# Altium schematic sheet CurrentAndVoltageSetRev2b.SchDoc
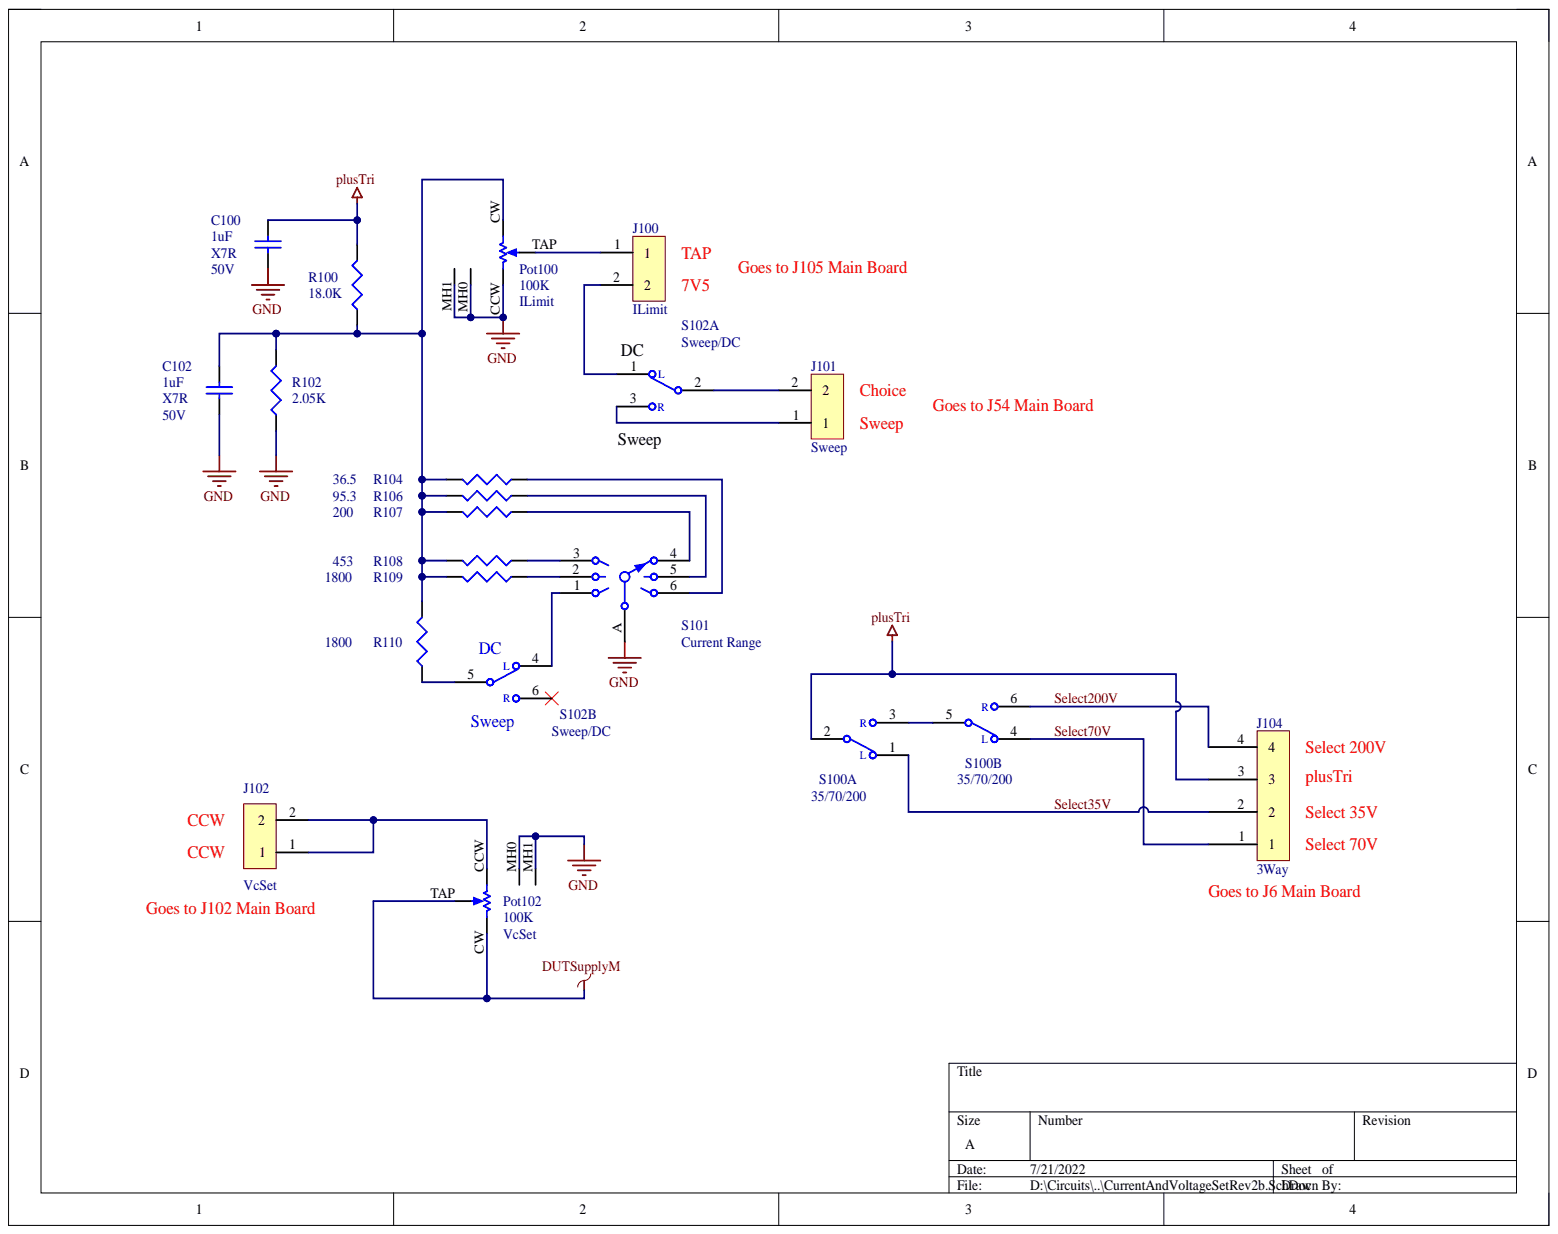
[diagram p0: CurrentAndVoltageSetRev2b.SchDoc]

## Parts
C100  1uF  Dielectric: X7R  Voltage: 50V  [CAPC2012M]
C102  1uF  Dielectric: X7R  Voltage: 50V  [CAPC2012M]
J100  ILimit  [DTA-100-2]
J101  Sweep  [DTA-100-2]
J102  VcSet  [DTA-100-2]
J104  3Way  [DTA-100-4]
Pot100  ILimit  value 100K  [P260T]
Pot102  VcSet  value 100K  [P260T]
R100  value 18.0K  [RESC2012M]  (hidden comment: 18K)
R102  2.05K  [RESC2012M]
R104  value 36.5  [RESC2012M]  (hidden comment: 36R5)
R106  value 95.3  [RESC2012M]  (hidden comment: 95R2)
R107  200  [RESC2012M]
R108  453  [RESC2012M]
R109  value 1800  [RESC2012M]  (hidden comment: 1.8K)
R110  value 1800  [RESC2012M]  (hidden comment: 1.8K)
S100  35/70/200  [M2024]  (parts A,B)
S101  Current Range  Series: A  [A106]
S102  Sweep/DC  [EG2414]  (parts A,B)

## Nets
DUTSupplyM: Pot102.CW Pot102.TAP
GND: C100.2 C102.2 Pot100.CCW Pot100.MH0 Pot100.MH1 Pot102.MH0 Pot102.MH1 R102.2 S101.A
plusTri: C100.1 J104.3 R100.1 S100.2
Select35V: J104.2 S100.1(L)
Select70V: J104.1 S100.4(L)
Select200V: J104.4 S100.6(R)
NetC102_1: C102.1 Pot100.CW R100.2 R102.1 R104.1 R106.1 R107.1 R108.1 R109.1 R110.1
NetJ100_1: J100.1 Pot100.TAP
NetJ100_2: J100.2 S102.1(L)
NetJ101_1: J101.1 S102.3(R)
NetJ101_2: J101.2 S102.2
NetJ102_1: J102.1 J102.2 Pot102.CCW
NetR104_2: R104.2 S101.6
NetR106_2: R106.2 S101.5
NetR107_2: R107.2 S101.4
NetR108_2: R108.2 S101.3
NetR109_2: R109.2 S101.2
NetR110_2: R110.2 S102.5
NetS100_3: S100.3(R) S100.5
NetS101_1: S101.1 S102.4(L)

Not connected: S102 6(R)

## Library data of the parts
C100 C102 = Cap: CAP CER 1UF 50V X7R 0805; Manufacturer: Samsung; Manufacturer Part Number: CL21B105KBFNNNE; Dielectric Material: Ceramic; Tolerance: 10%; Capacitance: 1uF; Case Code (Imperial): 0805; Case Code (Metric): 2012; Case/Package: 0805; Component Kind: Standard; Construction: Flat; Depth: 1.25mm; Height: 1.25mm; Length: 2mm; Library Name: Miscellaneous Devices.IntLib; Max Operating Temperature: 125°C; Min Operating Temperature: -55°C; Mount: Surface Mount; Number of Pins: 2; Package Quantity: 1; Packaging: Tape and Reel; Radiation Hardening: No; REACH SVHC: No SVHC; RoHS Compliant: Yes; Series: CL; Signal Integrity: Cap; Simulation: CAP; Supplier: Digi-Key; Supplier Part Number: 1276-1029-6-ND; Termination: SMD/SMT; Thickness: 0.053inch; Value: 1uF; Voltage Rating: 50V; Voltage Rating (DC): 50V; Width: 0.049inch
J100-J102 = 2-Pin: 2.54mm 2pin; Manufacturer: TE Connectivity; Manufacturer Part Number: 640456-2; Approvals: CSA; Backwall/Post Interruptions: No (Without); Circuit Application: Signal; Connector System: Wire-to-Board; Connector Type: Connector,Pin,Plug,Header; Contact Current Rating: 5A; Contact Post Length: 3.56mm; Contact Resistance: 6mR; Contact Style: Straight; Gender: Male; Mating Connector Lock: Yes (With); Max Voltage Rating (AC): 250V; Number of Contacts: 2; PCB Mount Retention: Without; PCB Mounting Orientation: Vertical; PCB Thickness: 0.063inch; Plating Thickness: 3.81um; Tail Length: 0.14inch; Value: 640456-2; Category: Headers and Wire Housings; Color: Natural,White,Beige; Component Kind: Standard; ComponentLink1Description: https://datasheet.ciiva.com/709/eng-cd-640456-w1-709680.pdf?src-supplier=Digi-Key; ComponentLink1URL: https://datasheet.ciiva.com/709/eng-cd-640456-w1-709680.pdf?src-supplier=Digi-Key; ComponentLink2Description: https://datasheet.ciiva.com/3118/eng-ds-82056-mta-0608-3118497.pdf?src-supplier=Avnet; ComponentLink2URL: https://datasheet.ciiva.com/3118/eng-ds-82056-mta-0608-3118497.pdf?src-supplier=Avnet; ComponentLink3Description: https://datasheet.ciiva.com/16401/eng-ds-82056-mta-0608-16401289.pdf?src-supplier=Future+Electronics; ComponentLink3URL: https://datasheet.ciiva.com/16401/eng-ds-82056-mta-0608-16401289.pdf?src-supplier=Future+Electronics; ComponentLink4Description: https://datasheet.ciiva.com/25617/0900766b80027008-25617244.pdf?src-supplier=RSComponents; ComponentLink4URL: https://datasheet.ciiva.com/25617/0900766b80027008-25617244.pdf?src-supplier=RSComponents; ComponentLink5Description: https://datasheet.ciiva.com/14156/04384301-14156820.pdf?src-supplier=Distrelec; ComponentLink5URL: https://datasheet.ciiva.com/14156/04384301-14156820.pdf?src-supplier=Distrelec; ComponentLink6Description: https://datasheet.ciiva.com/11746/0900766b80eb7fdd-11746365.pdf?src-supplier=RSComponents; ComponentLink6URL: https://datasheet.ciiva.com/11746/0900766b80eb7fdd-11746365.pdf?src-supplier=RSComponents; ComponentLink7Description: https://datasheet.ciiva.com/7128/1866390-7128742.pdf?src-supplier=Newark; ComponentLink7URL: https://datasheet.ciiva.com/7128/1866390-7128742.pdf?src-supplier=Newark; ComponentLink8Description: https://datasheet.ciiva.com/16505/eng-ds-82056-mta-0608-16505419.pdf?src-supplier=Avnet+Asia; ComponentLink8URL: https://datasheet.ciiva.com/16505/eng-ds-82056-mta-0608-16505419.pdf?src-supplier=Avnet+Asia; ComponentLink9Description: https://datasheet.ciiva.com/18620/1713017-18620870.pdf?src-supplier=Digi-Key; ComponentLink9URL: https://datasheet.ciiva.com/18620/1713017-18620870.pdf?src-supplier=Digi-Key; ComponentLink10Description: https://datasheet.ciiva.com/16047/1989748-16047438.pdf?src-supplier=Onlinecomponents.com; ComponentLink10URL: https://datasheet.ciiva.com/16047/1989748-16047438.pdf?src-supplier=Onlinecomponents.com; ComponentLink11Description: https://datasheet.ciiva.com/11758/0900766b811d404e-11758587.pdf?src-supplier=RSComponents; ComponentLink11URL: https://datasheet.ciiva.com/11758/0900766b811d404e-11758587.pdf?src-supplier=RSComponents; ComponentLink12Description: https://datasheet.ciiva.com/6746/combiconspring-cagepcbterm-blocks-6746175.pdf?src-supplier=Digi-Key; ComponentLink12URL: https://datasheet.ciiva.com/6746/combiconspring-cagepcbterm-blocks-6746175.pdf?src-supplier=Digi-Key; ComponentLink13Description: https://datasheet.ciiva.com/14607/70055059-14607702.pdf?src-supplier=Allied+Electronics; ComponentLink13URL: https://datasheet.ciiva.com/14607/70055059-14607702.pdf?src-supplier=Allied+Electronics; ComponentLink14Description: https://datasheet.ciiva.com/16051/1988956-16051359.pdf?src-supplier=Onlinecomponents.com; ComponentLink14URL: https://datasheet.ciiva.com/16051/1988956-16051359.pdf?src-supplier=Onlinecomponents.com; ComponentLink15Description: https://datasheet.ciiva.com/26340/567541-da-01-de-printklemme-1988956-250-st-26340451.pdf?src-supplier=Conrad; ComponentLink15URL: https://datasheet.ciiva.com/26340/567541-da-01-de-printklemme-1988956-250-st-26340451.pdf?src-supplier=Conrad; ComponentLink16Description: https://datasheet.ciiva.com/824/combiconcompactseries-824224.pdf?src-supplier=Digi-Key; ComponentLink16URL: https://datasheet.ciiva.com/824/combiconcompactseries-824224.pdf?src-supplier=Digi-Key; ComponentLink17Description: https://datasheet.ciiva.com/14609/70055171-14609100.pdf?src-supplier=Allied+Electronics; ComponentLink17URL: https://datasheet.ciiva.com/14609/70055171-14609100.pdf?src-supplier=Allied+Electronics; Contact Gender: Male; Contact Material: Copper Alloy; Contact Mating Length: 7.49mm; Contact Plating: Tin; Contact Retention: No (Without); Contact Shape: Square; Contact Type: Male Pin; Current Rating: 5A; Depth: 5.72mm; ELV: Compliant; Fastening Type: Friction; Flammability Rating: UL94 V-0; Height: 7.87mm; Housing Color: Natural; Housing Material: Polyester; Lead Free: Lead Free; Lead Pitch: 2.54mm; Length: 7.62mm; Library Name: MY_CONNECTORS.SchLib; Mating Alignment: No (Without); Mating Retention: No (Without); Max Operating Temperature: 221°C; Min Operating Temperature: -67°C; Mount: Through Hole; Mounting Ears: No (Without); Number of Poles: 2; Number of Positions: 2; Number of Rows: 1; Orientation: Straight; Package Quantity: 5000; Packaging: Bulk; Panel Mount Retention: No (Without); PCB Mount Alignment: No (Without); Pitch: 2.54mm; Pricing 1: 1=0.13000, 10=0.10400, 100=0.07700, 500=0.06374, 1000=0.05578, 5000=0.04781, 10000=0.04648 (USD); Radiation Hardening: No; REACH SVHC: No SVHC; RoHS: Yes; RoHS Compliant: Yes; Sealable: No (Without); Series: MTA-100; Shrouded: No (Without); Stock 1: 18986; Strain Relief: No (Without); Supplier: Digi-Key; Supplier Part Number: A1921-ND; Termination: Solder; Voltage Rating: 250V; Voltage Rating (AC): 250V; Working Voltage: 250V
J104 = 4-Pin: 2.54mm 4pin; Manufacturer: TE Connectivity; Manufacturer Part Number: 640456-4; Approvals: CSA; Backwall/Post Interruptions: No (Without); Circuit Application: Signal; Connector System: Wire-to-Board; Connector Type: Connector,Pin,Plug,Header; Contact Current Rating: 5A; Contact Post Length: 3.56mm; Contact Resistance: 6mR; Contact Style: Straight; Mating Connector Lock: Yes (With); Max Voltage Rating (AC): 250V; Number of Contacts: 4; PCB Mount Retention: Without; PCB Mounting Orientation: Vertical; PCB Thickness: 0.063inch; Plating Thickness: 3.81um; Tail Length: 0.14inch; Value: 640456-4; Category: Connectors; Color: Natural,Beige,White; Component Kind: Standard; ComponentLink1Description: https://datasheet.ciiva.com/9525/eng-ds-82056-mta-0608-9525088.pdf?src-supplier=Avnet; ComponentLink1URL: https://datasheet.ciiva.com/9525/eng-ds-82056-mta-0608-9525088.pdf?src-supplier=Avnet; ComponentLink2Description: https://datasheet.ciiva.com/36859/38054-36859312.pdf?src-supplier=Farnell; ComponentLink2URL: https://datasheet.ciiva.com/36859/38054-36859312.pdf?src-supplier=Farnell; ComponentLink3Description: https://datasheet.ciiva.com/16286/eng-ds-82056-mta-0608-16286228.pdf?src-supplier=Future+Electronics; ComponentLink3URL: https://datasheet.ciiva.com/16286/eng-ds-82056-mta-0608-16286228.pdf?src-supplier=Future+Electronics; ComponentLink4Description: https://datasheet.ciiva.com/19462/613958-19462402.pdf?src-supplier=Jameco; ComponentLink4URL: https://datasheet.ciiva.com/19462/613958-19462402.pdf?src-supplier=Jameco; ComponentLink5Description: https://datasheet.ciiva.com/11746/0900766b80027008-11746331.pdf?src-supplier=RSComponents; ComponentLink5URL: https://datasheet.ciiva.com/11746/0900766b80027008-11746331.pdf?src-supplier=RSComponents; ComponentLink6Description: https://datasheet.ciiva.com/25618/0900766b80eb7fdd-25618242.pdf?src-supplier=RSComponents; ComponentLink6URL: https://datasheet.ciiva.com/25618/0900766b80eb7fdd-25618242.pdf?src-supplier=RSComponents; ComponentLink7Description: https://datasheet.ciiva.com/709/eng-cd-640456-w2-709689.pdf?src-supplier=Digi-Key; ComponentLink7URL: https://datasheet.ciiva.com/709/eng-cd-640456-w2-709689.pdf?src-supplier=Digi-Key; ComponentLink8Description: https://datasheet.ciiva.com/14637/70083644-14637814.pdf?src-supplier=Allied+Electronics; ComponentLink8URL: https://datasheet.ciiva.com/14637/70083644-14637814.pdf?src-supplier=Allied+Electronics; ComponentLink9Description: https://datasheet.ciiva.com/14156/mta-cst-100-sl-156-eng-datasheet-14156822.pdf?src-supplier=Distrelec; ComponentLink9URL: https://datasheet.ciiva.com/14156/mta-cst-100-sl-156-eng-datasheet-14156822.pdf?src-supplier=Distrelec; ComponentLink10Description: https://datasheet.ciiva.com/16656/eng-ds-82056-mta-0608-16656524.pdf?; ComponentLink10URL: https://datasheet.ciiva.com/16656/eng-ds-82056-mta-0608-16656524.pdf?; Contact Gender: Male; Contact Material: Copper Alloy; Contact Mating Length: 7.49mm; Contact Plating: Tin; Contact Retention: No (Without); Contact Shape: Square; Current Rating: 5A; Depth: 5.72mm; Fastening Type: Friction; Flammability Rating: UL94 V-0; Height: 7.87mm; Housing Color: Natural; Housing Material: Polyester; Lead Pitch: 2.54mm; Length: 12.7mm; Library Name: MY_CONNECTORS.SchLib; Mating Alignment: No (Without); Mating Retention: No (Without); Max Operating Temperature: 221°C; Min Operating Temperature: -67°C; Mount: Through Hole; Mounting Ears: No (Without); Number of Poles: 4; Number of Positions: 4; Number of Rows: 1; Orientation: Straight; Package Quantity: 5000; Packaging: Bulk; Panel Mount Retention: No (Without); PCB Mount Alignment: No (Without); Pitch: 2.54mm; Pricing 1: 1=0.28000, 10=0.23600, 100=0.18120, 500=0.15336, 1000=0.12548, 5000=0.11154, 10000=0.10108 (USD); Radiation Hardening: No; REACH SVHC: No SVHC; RoHS: Yes; RoHS Compliant: Yes; Sealable: No (Without); Series: MTA-100; Shrouded: No (Without); Stock 1: 72163; Strain Relief: No (Without); Supplier: Digi-Key; Supplier Part Number: A1922-ND; Termination: Solder; Voltage Rating: 250V; Voltage Rating (AC): 250V; Weight: 0.01411oz; Working Voltage: 250V
Pot100 Pot102 = POT-CW-Single-MH: Res Conductive Plastic Potentiometer 100K Ohm 10% Panel Mount/Through Hole; Manufacturer: TT BI; Manufacturer Part Number: P260T-D1BS3CB100K; Actuator Diameter: 0.25inch; Actuator Length: 0.787inch; Max Power Dissipation: 500mW; Number of Gangs: 1; Resistance: 100kR; Category: Variable Resistors and Potentiometers; Component Kind: Standard; ComponentLink1Description: https://datasheet.ciiva.com/26859/getdatasheetpartid-739963-26859664.pdf?src-supplier=Verical; ComponentLink1URL: https://datasheet.ciiva.com/26859/getdatasheetpartid-739963-26859664.pdf?src-supplier=Verical; ComponentLink2Description: https://datasheet.ciiva.com/48425/p260-eng-tds-48425285.pdf?src-supplier=Distrelec; ComponentLink2URL: https://datasheet.ciiva.com/48425/p260-eng-tds-48425285.pdf?src-supplier=Distrelec; Depth: 14.25mm; Length: 12.7mm; Max Operating Temperature: 125°C; Military Standard: Not; Min Operating Temperature: -55°C; Mount: Panel; Number of Turns: 1; Package Quantity: 240; Packaging: Tray; Pricing 1: 1=5.52000, 10=5.06000, 25=4.50800, 40=4.23200, 80=3.86400, 230=3.12800, 840=2.85200, 1680=2.76000 (USD); Radiation Hardening: No; Resistor Type: Conductive Plastic; RoHS: Yes; Series: P260; Stock 1: 839; Supplier: Digi-Key; Supplier Part Number: 987-1335-ND; Taper: Linear; Technology: CONDUCTIVE PLASTIC; Termination: PCB; Tolerance: 10%; Type: Potentiometer; Library Name: MY_POTS.SchLib
R100 = Res3: RES 18K OHM 1% 1/4W 0805; Manufacturer: KOA Speer; Manufacturer Part Number: RK73H2ATTD1802F; Max Power Dissipation: 250mW; Power Rating: 125mW; Resistance: 18kR; Temperature Coefficient: 100ppm/°C; Voltage Rating: 150V; Voltage Rating (DC): 200V; Case Code (Imperial): 0805; Case Code (Metric): 2012; Case/Package: 0805; Component Kind: Standard; Composition: Thick Film; Contact Plating: Matte,Tin; Depth: 1.6mm; Features: Automotive AEC-Q200; Height: 0.5mm; Lead Free: Lead Free; Length: 2mm; Library Name: Miscellaneous Devices.IntLib; Max Operating Temperature: 155°C; Military Standard: Not; Min Operating Temperature: -55°C; Mount: Surface Mount; Number of Pins: 2; Number of Terminations: 2; Package Quantity: 5000; Packaging: Tape and Reel; Radiation Hardening: No; REACH SVHC: Unknown; Resistance Tolerance: 1%; Resistor Type: Thick Film; RoHS Compliant: Yes; Series: RK73H; Signal Integrity: Res; Simulation: RESISTOR; Supplier: Digi-Key; Supplier Part Number: 2019-RK73H2ATTD1802FCT-ND; Termination: SMD/SMT; Tolerance: 1%; Width: 1.25mm
R102 = Res3: RES 2.05K OHM 1% 1/4W 0805; Manufacturer: KOA Speer; Manufacturer Part Number: RK73H2ATTD2051F; Manufacturer Series: RK73H; Additional Feature: PRECISION; Power Rating: 125mW; Resistance: 2.05kR; Temperature Coefficient: 100ppm/°C; Voltage Rating: 150V; Case Code (Imperial): 0805; Case Code (Metric): 2012; Case/Package: 0805; Component Kind: Standard; Construction: Rectangular; Depth: 1.25mm; Height: 0.5mm; Lead Free: Lead Free; Length: 2mm; Library Name: Miscellaneous Devices.IntLib; Max Operating Temperature: 155°C; Military Standard: Not; Min Operating Temperature: -55°C; Package Quantity: 5000; Package Shape: Rectangular; Packaging: Tape and Reel; Resistor Type: Thick Film; RoHS Compliant: Yes; Series: RK73H; Signal Integrity: Res; Simulation: RESISTOR; Size Code: 0805; Supplier: Digi-Key; Supplier Part Number: 2019-RK73H2ATTD2051FDKR-ND; Technology: METAL GLAZE/THICK FILM; Terminal Finish: Tin; Termination: SMD/SMT; Tolerance: 1%; Value: 2.05K; Voltage Rating (DC): 150V; Width: 1.25mm; Working Voltage: 150V
R104 = Res3: RES 36R5 OHM 1% 1/4W 0805; Manufacturer: KOA Speer; Manufacturer Part Number: RK73H2ATTD36R5F; Power Rating: 125mW; Resistance: 36.5R; Temperature Coefficient: 100ppm/°C; Voltage Rating: 150V; Case Code (Imperial): 0805; Case Code (Metric): 2012; Case/Package: 0805; Component Kind: Standard; Construction: Rectangular; Depth: 1.25mm; Height: 0.5mm; Length: 2mm; Library Name: Miscellaneous Devices.IntLib; Max Operating Temperature: 155°C; Military Standard: Not; Min Operating Temperature: -55°C; Package Quantity: 5000; Packaging: Tape and Reel; Resistor Type: Thick Film; RoHS Compliant: Yes; Series: RK73H; Signal Integrity: Res; Simulation: RESISTOR; Supplier: Digi-Key; Supplier Part Number: 2019-RK73H2ATTD36R5FCT-ND; Termination: SMD/SMT; Tolerance: 1%; Voltage Rating (DC): 150V; Width: 1.25mm
R106 = Res3: RES 95R3 OHM 1% 1/4W 0805; Manufacturer: KOA Speer; Manufacturer Part Number: RK73H2ATTD95R3F; Power Rating: 125mW; Resistance: 95.3R; Temperature Coefficient: 100ppm/°C; Voltage Rating: 150V; Case Code (Imperial): 0805; Case Code (Metric): 2012; Case/Package: 0805; Component Kind: Standard; Construction: Rectangular; Depth: 1.25mm; Height: 0.5mm; Length: 2mm; Library Name: Miscellaneous Devices.IntLib; Max Operating Temperature: 155°C; Military Standard: Not; Min Operating Temperature: -55°C; Package Quantity: 5000; Packaging: Tape and Reel; Resistor Type: Thick Film; RoHS Compliant: Yes; Series: RK73H; Signal Integrity: Res; Simulation: RESISTOR; Supplier: Digi-Key; Supplier Part Number: 2019-RK73H2ATTD95R3FCT-ND; Termination: SMD/SMT; Tolerance: 1%; Voltage Rating (DC): 150V; Width: 1.25mm
R107 = Res3: RES 200 OHM 1% 1/4W 0805; Manufacturer: KOA Speer; Manufacturer Part Number: RK73H2ATTD2000F; Power Rating: 125mW; Resistance: 200R; Temperature Coefficient: 100ppm/°C; Voltage Rating: 150V; Case Code (Imperial): 0805; Case Code (Metric): 2012; Case/Package: 0805; Component Kind: Standard; Construction: Rectangular; Depth: 1.25mm; Height: 0.5mm; Lead Free: Lead Free; Length: 2mm; Library Name: Miscellaneous Devices.IntLib; Max Operating Temperature: 155°C; Military Standard: Not; Min Operating Temperature: -55°C; Number of Pins: 2; Package Quantity: 5000; Packaging: Tape and Reel; Resistance Tolerance: 1%; Resistor Type: Thick Film; RoHS Compliant: Yes; Series: RK73H; Signal Integrity: Res; Simulation: RESISTOR; Supplier: Digi-Key; Supplier Part Number: 2019-RK73H2ATTD2000FCT-ND; Termination: SMD/SMT; Tolerance: 1%; Value: 200; Voltage Rating (DC): 150V; Width: 1.25mm
R108 = Res3: RES 453 OHM 1% 1/4W 0805; Manufacturer: KOA Speer; Manufacturer Part Number: RK73H2ATTD4530F; Power Rating: 125mW; Resistance: 453R; Temperature Coefficient: 100ppm/°C; Voltage Rating: 150V; Case Code (Imperial): 0805; Case Code (Metric): 2012; Case/Package: 0805; Component Kind: Standard; Construction: Rectangular; Depth: 1.25mm; Height: 0.5mm; Lead Free: Lead Free; Length: 2mm; Library Name: Miscellaneous Devices.IntLib; Max Operating Temperature: 155°C; Military Standard: Not; Min Operating Temperature: -55°C; Package Quantity: 5000; Packaging: Tape and Reel; Resistor Type: Thick Film; RoHS Compliant: Yes; Series: RK73H; Signal Integrity: Res; Simulation: RESISTOR; Supplier: Digi-Key; Supplier Part Number: 2019-RK73H2ATTD4530FCT-ND; Termination: SMD/SMT; Tolerance: 1%; Value: 453; Voltage Rating (DC): 150V; Width: 1.25mm
R109 R110 = Res3: RES 1.8K OHM 1% 1/4W 0805; Manufacturer: KOA Speer; Manufacturer Part Number: RK73H2ATTD1801F; Power Rating: 125mW; Resistance: 1.8kR; Temperature Coefficient: 100ppm/°C; Voltage Rating: 150V; Case Code (Imperial): 0805; Case Code (Metric): 2012; Case/Package: 0805; Component Kind: Standard; Construction: Rectangular; Depth: 1.25mm; Height: 0.5mm; Lead Free: Lead Free; Length: 2mm; Library Name: Miscellaneous Devices.IntLib; Max Operating Temperature: 155°C; Military Standard: Not; Min Operating Temperature: -55°C; Number of Pins: 2; Package Quantity: 5000; Packaging: Tape and Reel; Resistance Tolerance: 1%; Resistor Type: Thick Film; RoHS Compliant: Yes; Series: RK73H; Signal Integrity: Res; Simulation: RESISTOR; Supplier: Digi-Key; Supplier Part Number: 2019-RK73H2ATTD1801FCT-ND; Termination: SMD/SMT; Tolerance: 1%; Width: 1.25mm
S100 = 3PDT: Switch Toggle ON ON ON SP3T Small Bat Lever PC Pins 6A 250VAC 30VDC PC Mount with Threads; Manufacturer: NKK Switches; Manufacturer Part Number: M2024SS1W03; Actuator Length: 10.5mm; Actuator Material: Brass,Chrome; Actuator Type: Standard Round; Current Rating: 3A; Electrical Life: 25000Cycles; Illumination Type: Non-Illuminated,Illuminated; Max Voltage Rating (AC): 250V; Max Voltage Rating (DC): 30V; Number of Poles: 1; Number of Positions: 3; Switch Function: On-On-On; Value: M2024; Category: Toggle Switches; Component Kind: Standard; ComponentLink1Description: https://datasheet.ciiva.com/2905/st131017-2905547.pdf?src-supplier=Digi-Key; ComponentLink1URL: https://datasheet.ciiva.com/2905/st131017-2905547.pdf?src-supplier=Digi-Key; ComponentLink2Description: https://datasheet.ciiva.com/2909/t126001c-2909437.pdf?src-supplier=Digi-Key; ComponentLink2URL: https://datasheet.ciiva.com/2909/t126001c-2909437.pdf?src-supplier=Digi-Key; ComponentLink3Description: https://datasheet.ciiva.com/2905/mtogglesbushing-2905108.pdf?src-supplier=Digi-Key; ComponentLink3URL: https://datasheet.ciiva.com/2905/mtogglesbushing-2905108.pdf?src-supplier=Digi-Key; ComponentLink4Description: https://datasheet.ciiva.com/5286/mtogglesbushing-31423-5286966.pdf?src-supplier=Mouser; ComponentLink4URL: https://datasheet.ciiva.com/5286/mtogglesbushing-31423-5286966.pdf?src-supplier=Mouser; ComponentLink5Description: https://datasheet.ciiva.com/28401/1749445-28401023.pdf?src-supplier=Element14; ComponentLink5URL: https://datasheet.ciiva.com/28401/1749445-28401023.pdf?src-supplier=Element14; Contact Material: Silver; Contact Plating: Silver; Depth: 12.7mm; Lead Free: Lead Free; Length: 13mm; Library Name: MY_SWITCH.SchLib; Max Current Rating: 6A; Max Operating Temperature: 85°C; Min Operating Temperature: -30°C; Mount: Panel; Orientation: Vertical; Package Quantity: 1; Packaging: Bulk; Pricing 1: 1=7.78000, 10=6.99000, 25=6.66680, 50=6.38020, 100=6.12930, 250=5.80672, 500=5.37660, 1000=5.12569 (USD); REACH SVHC: No SVHC; RoHS: No; RoHS Compliant: No; Series: M; Stock 1: 107; Supplier: Digi-Key; Supplier Part Number: 360-2074-ND; Termination: PC Pin; Throw Configuration: SP3T; Voltage Rating (AC): 250V; Voltage Rating (DC): 30V; Width: 7.9mm
S101 = 6Way-SW: Switch Rotary N.O./N.C. SP6T 6 Flatted Shaft PC Pins 2.5A 125VAC 125VDC Automotive; Manufacturer: ITT C&K; Manufacturer Part Number: A10605RNCQ; Actuator Length: 12.7mm; Actuator Material: Nylon,Glass; Contact Style: Non-Shorting; Electrical Life: 300000Cycles; Illumination Type: Non-Illuminated; Index Angle: 30°; Index Stops: Fixed; Max Voltage Rating (AC): 125V; Number of Decks: 1; Number of Positions: 6; Value: A10605RNCQ; Category: Rotary Switches; Component Kind: Standard; ComponentLink1Description: https://datasheet.ciiva.com/5278/arotary-31aug10-38283-5278208.pdf?src-supplier=Mouser; ComponentLink1URL: https://datasheet.ciiva.com/5278/arotary-31aug10-38283-5278208.pdf?src-supplier=Mouser; Contact Material: Silver; Contact Plating: Silver; Current Rating: 2.5A; Depth: 26.19mm; Housing Material: Nylon; Length: 26.19mm; Library Name: MY_SWITCH.SchLib; Max Current Rating: 2.5A; Max Operating Temperature: 85°C; Max Voltage Rating (DC): 125V; Min Operating Temperature: -30°C; Mount: Panel,Through Hole; Number of Poles per Deck: 1; Orientation: Straight; Package Quantity: 1; Packaging: Bulk; Pricing 1: 1=7.57000, 5=6.49800, 10=6.08400, 36=5.57806, 72=5.21028, 108=4.90380, 252=4.49004, 468=4.21421, 972=3.98434 (USD); Radiation Hardening: No; RoHS: Yes; RoHS Compliant: Yes; Stock 1: 429; Supplier: Digi-Key; Supplier Part Number: CKN10615-ND; Termination: PC Pins; Throw Configuration: SP6T; Voltage Rating (AC): 125V; Voltage Rating (DC): 125V
S102 = 4PDT-Slide: Switch Toggle - Miniature; Manufacturer: E-Switch; Manufacturer Part Number: 100DP1T1B1M2QE; Actuator Length: 10.41mm; Actuator Material: Brass,Chrome; Actuator Type: Standard Round; Current Rating: 5A; Electrical Life: 40000Cycles; Illumination Type: Non-Illuminated; Switch Function: On-On; Throw Configuration: DPDT; Value: DPDT On-On; Voltage Rating (AC): 120V; Voltage Rating (DC): 28V; Category: Toggle Switches; Component Kind: Standard; ComponentLink1Description: https://datasheet.ciiva.com/2904/sp1004-series-jpg-2904855.pdf?src-supplier=Digi-Key; ComponentLink1URL: https://datasheet.ciiva.com/2904/sp1004-series-jpg-2904855.pdf?src-supplier=Digi-Key; ComponentLink2Description: https://datasheet.ciiva.com/6453/100-6453407.pdf?src-supplier=Digi-Key; ComponentLink2URL: https://datasheet.ciiva.com/6453/100-6453407.pdf?src-supplier=Digi-Key; Library Name: MY_SWITCH.SchLib; Max Operating Temperature: 85°C; Min Operating Temperature: -30°C; Mount: Panel; Package Quantity: 200; Packaging: Bulk; Pricing 1: 1=3.15000, 10=2.82700, 25=2.67120, 50=2.55380, 100=2.42350, 250=2.31924, 500=2.13684, 1000=2.03260 (USD); RoHS: No; RoHS Compliant: No; Series: 100; Stock 1: 419; Supplier: Digi-Key; Supplier Part Number: 100DP1T1B1M2QE-ND; Termination: PC Pin
